# Altium schematic sheet DSD_Aerobits_RPI4_OLED.SchDoc
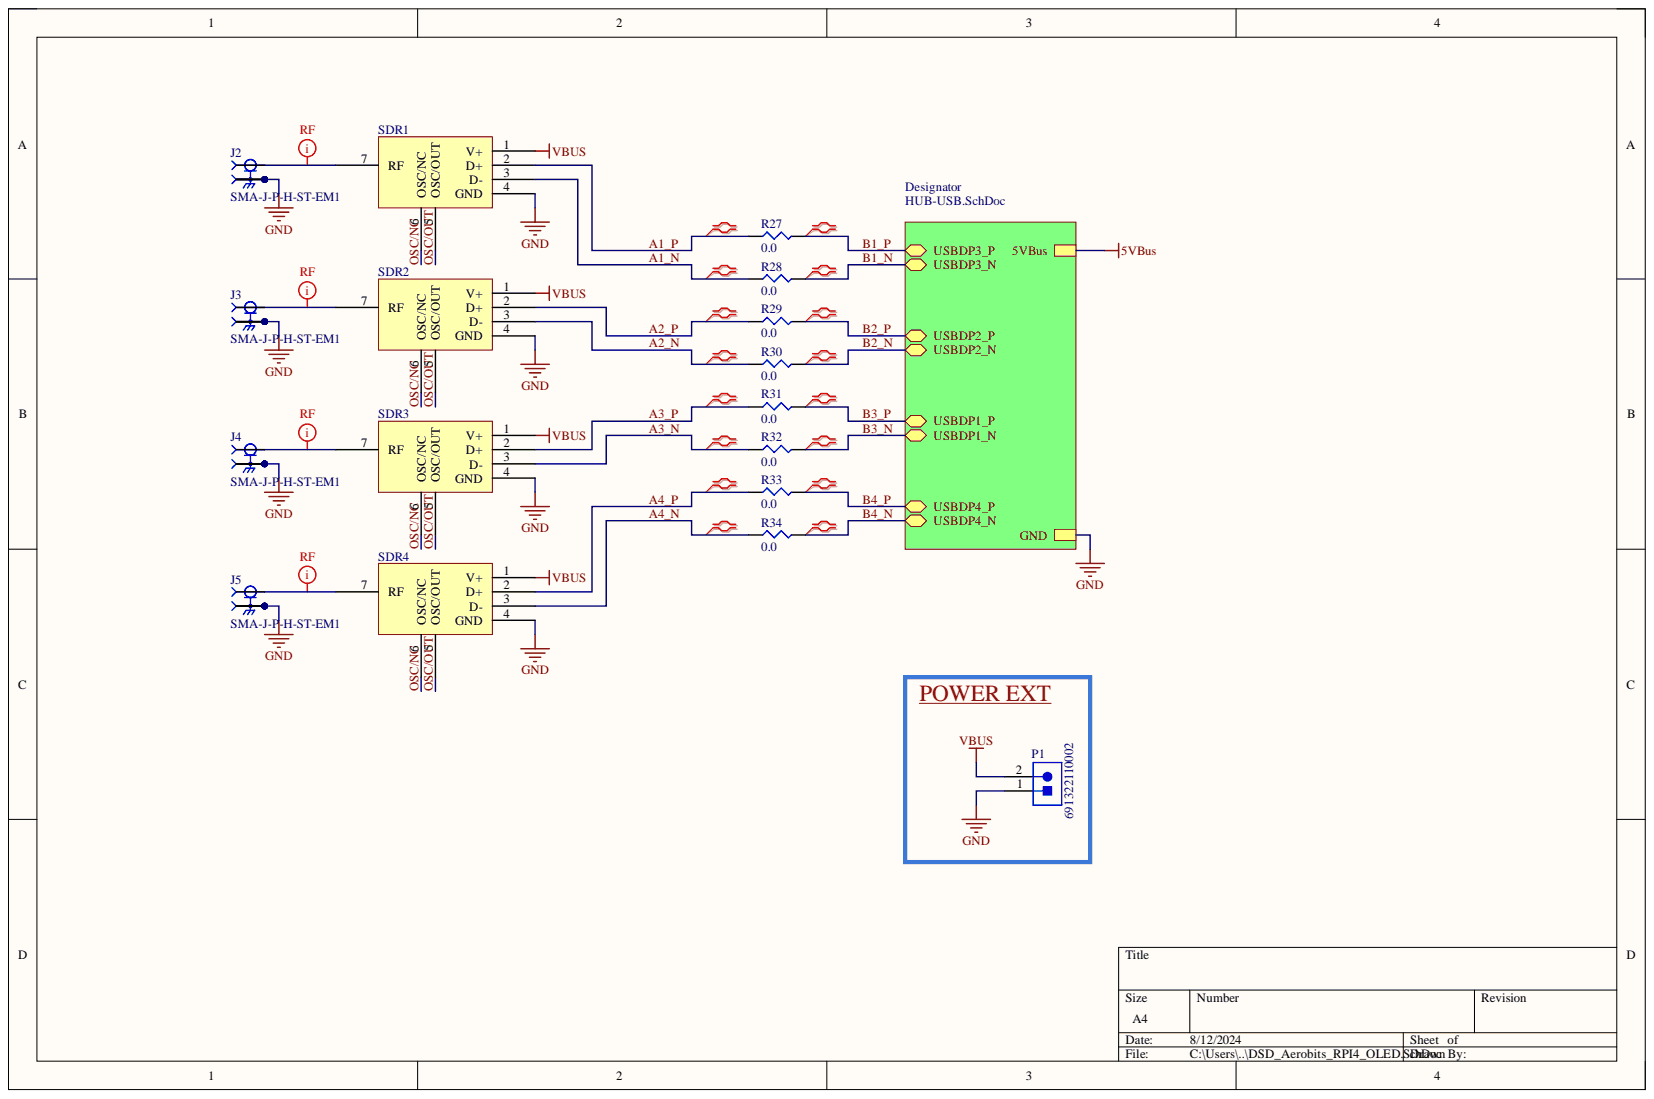
[diagram p0: DSD_Aerobits_RPI4_OLED.SchDoc]

## Parts
J2  SMA-J-P-H-ST-EM1  [SAMTEC_SMA-J-P-H-ST-EM1]
J3  SMA-J-P-H-ST-EM1  [SAMTEC_SMA-J-P-H-ST-EM1]
J4  SMA-J-P-H-ST-EM1  [SAMTEC_SMA-J-P-H-ST-EM1]
J5  SMA-J-P-H-ST-EM1  [SAMTEC_SMA-J-P-H-ST-EM1]
P1  691322110002  [691322110002]
R27  0.0  [SMD-0603-RES]
R28  0.0  [SMD-0603-RES]
R29  0.0  [SMD-0603-RES]
R30  0.0  [SMD-0603-RES]
R31  0.0  [SMD-0603-RES]
R32  0.0  [SMD-0603-RES]
R33  0.0  [SMD-0603-RES]
R34  0.0  [SMD-0603-RES]
SDR1  SDR_Module  [SDR]  (value not drawn)
SDR2  SDR_Module  [SDR]  (value not drawn)
SDR3  SDR_Module  [SDR]  (value not drawn)
SDR4  SDR_Module  [SDR]  (value not drawn)

## Nets
GND: J2.2_1 J2.2_2 J2.3_1 J2.3_2 J3.2_1 J3.2_2 J3.3_1 J3.3_2 J4.2_1 J4.2_2 J4.3_1 J4.3_2 J5.2_1 J5.2_2 J5.3_1 J5.3_2 P1.1 SDR1.4(GND) SDR2.4(GND) SDR3.4(GND) SDR4.4(GND)
VBUS: P1.2 SDR1.1(V+) SDR2.1(V+) SDR3.1(V+) SDR4.1(V+)
A1_N: R28.1 SDR1.3(D-)
A1_P: R27.1 SDR1.2(D+)
A2_N: R30.1 SDR2.3(D-)
A2_P: R29.1 SDR2.2(D+)
A3_N: R32.1 SDR3.3(D-)
A3_P: R31.1 SDR3.2(D+)
A4_N: R34.1 SDR4.3(D-)
A4_P: R33.1 SDR4.2(D+)
B1_N: R28.2
B1_P: R27.2
B2_N: R30.2
B2_P: R29.2
B3_N: R32.2
B3_P: R31.2
B4_N: R34.2
B4_P: R33.2
OSC/NC: SDR1.6(OSC/NC) SDR2.6(OSC/NC) SDR3.6(OSC/NC) SDR4.6(OSC/NC)
OSC/OUT: SDR1.5(OSC/OUT) SDR2.5(OSC/OUT) SDR3.5(OSC/OUT) SDR4.5(OSC/OUT)
NetJ2_1: J2.1 SDR1.7(RF)
NetJ3_1: J3.1 SDR2.7(RF)
NetJ4_1: J4.1 SDR3.7(RF)
NetJ5_1: J5.1 SDR4.7(RF)
5VBus:

## Library data of the parts
J2-J5 = SMA-J-P-H-ST-EM1: SMA Connector Jack, Female Socket 50Ohm Board Edge, End Launch Solder; MF: Samtec; Availability: Unavailable; Component Kind: Standard; Library Name: SMA-J-P-H-ST-EM1.IntLib; MP: SMA-J-P-H-ST-EM1; Package: None; Price: None
P1 = 691322110002: WR-TBL Serie 3221 Horizontal PCB Header, Pluggable, THT, pitch 3.5mm, 2p; Manufacturer: Wurth Elektronik; Modularity: No; Pin Number: 2; Rated Current [UL]: 10A; Working Voltage [UL]: 300VAC; Application: Pluggable; Component Kind: Standard; ComponentLink1Description: Manufacturer; ComponentLink1URL: http://www.we-online.de; ComponentLink2Description: Datasheet; ComponentLink2URL: https://katalog.we-online.de/em/datasheet/691322110002.pdf; Library Name: Altium_WR-TBL (rev21a).IntLib; Manufacturer Part Number: 691322110002; Mounting Technology: THT; Operating Temperature: -40 to +105degC; Packaging: Box; PCB/Cable/Panel: PCB; Pitch: 3.5mm; Published Date: Feburary 2021; Type: Horizontal
R27-R34 = ERJ-3GEY0R00V: RES SMD 0.0OHM JUMPER 1/10W 0603; Manufacturer: Panasonic Electronic Components; @ qty: 1500; Database Table Name: Panasonic_ERJ_0603; Factory Stock: 0; Minimum Quantity: 1; Power (Watts): 0.1W, 1/10W; Unit Price (USD): 0.00352; Component Kind: Standard; Composition: Thick Film; Datasheets: http://industrial.panasonic.com/www-cgi/jvcr13pz.cgi?E+PZ+3+AOA0001+ERJ3GEY0R00V+7+WW; Digi-Key Part Number: P0.0GCT-ND; Failure Rate: -; Features: Automotive AEC-Q200; Height - Seated (Max): 0.022" (0.55mm); Image: //media.digikey.com/Photos/Panasonic%20Photos/MFG_ERJ-P6W%20Series.jpg; Number of Terminations: 2; Operating Temperature: -55°C ~ 155°C; Package / Case: 0603 (1608 Metric); Packaging: Cut Tape (CT); Part Number: ERJ-3GEY0R00V; Part Status: Active; Quantity Available: 4813372; Series: ERJ; Size / Dimension: 0.063" L x 0.031" W (1.60mm x 0.80mm); Supplier Device Package: 0603; Temperature Coefficient: -; Tolerance: Jumper; Value: 0.0; Library Name: res_panasonic_erj_xls.DbLib
SDR1-SDR4 = SDR_Module: Component Kind: Standard; Library Name: SDR_Module.SchLib
